# Altium schematic sheet ADC Schematic.SchDoc("ADC Schematic")
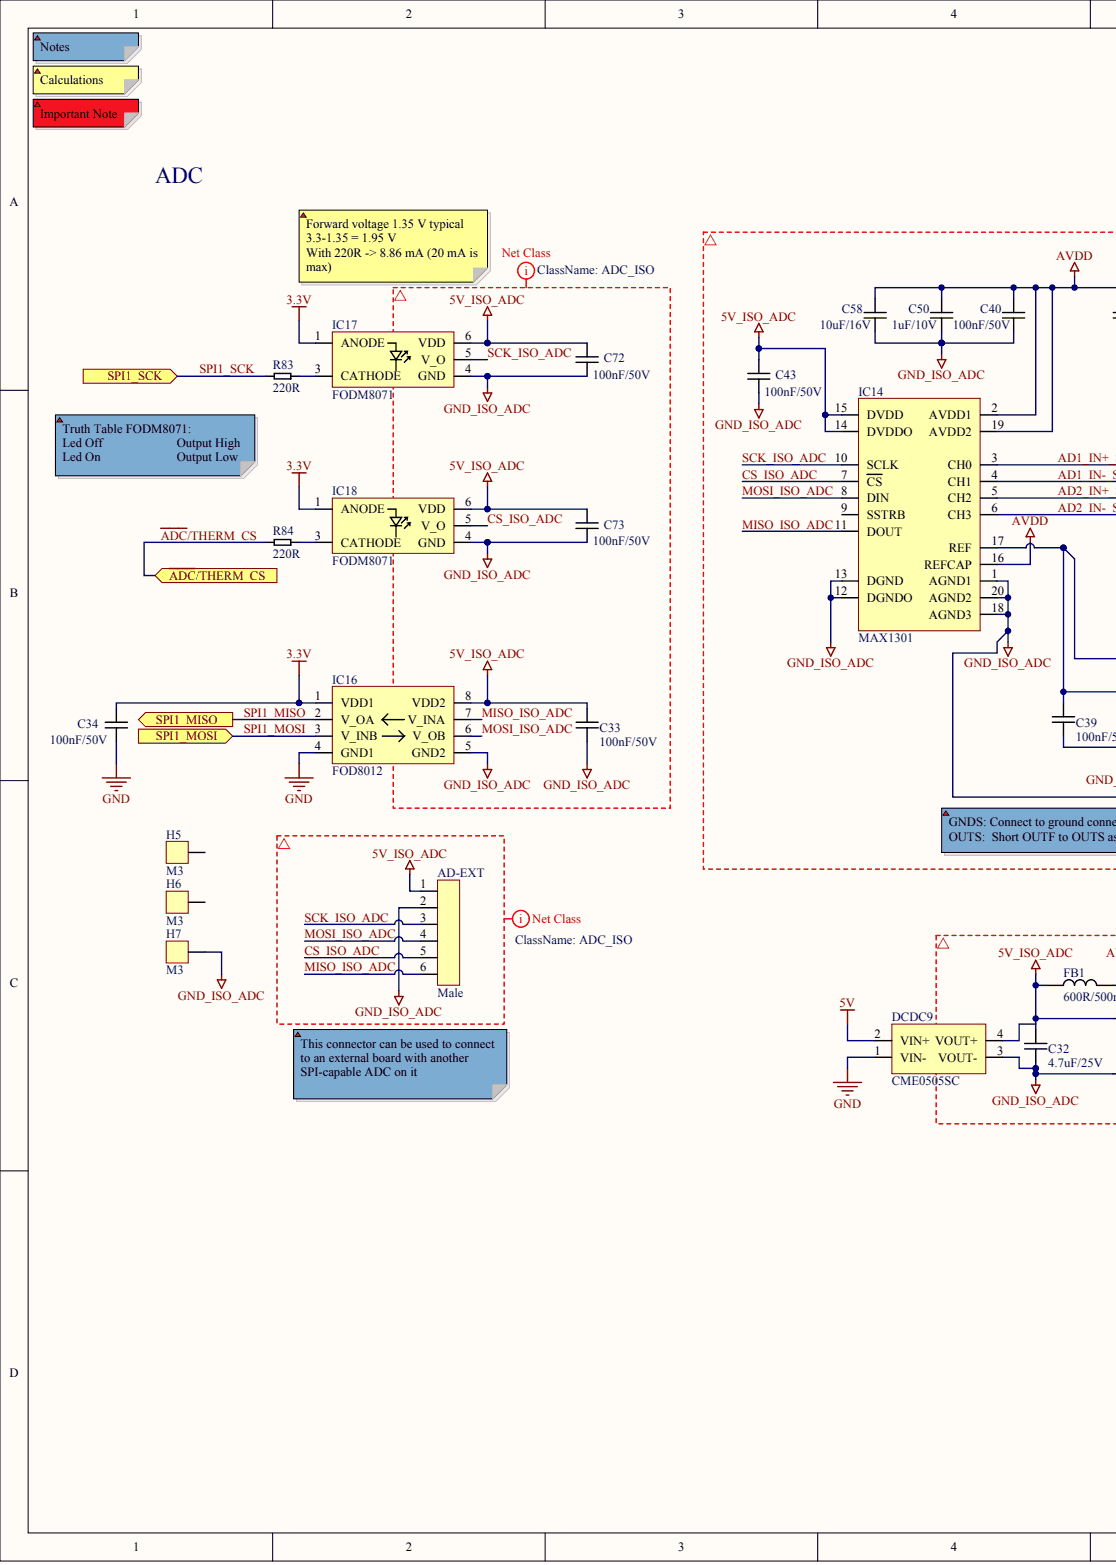
[diagram p0: ADC Schematic.SchDoc, left]
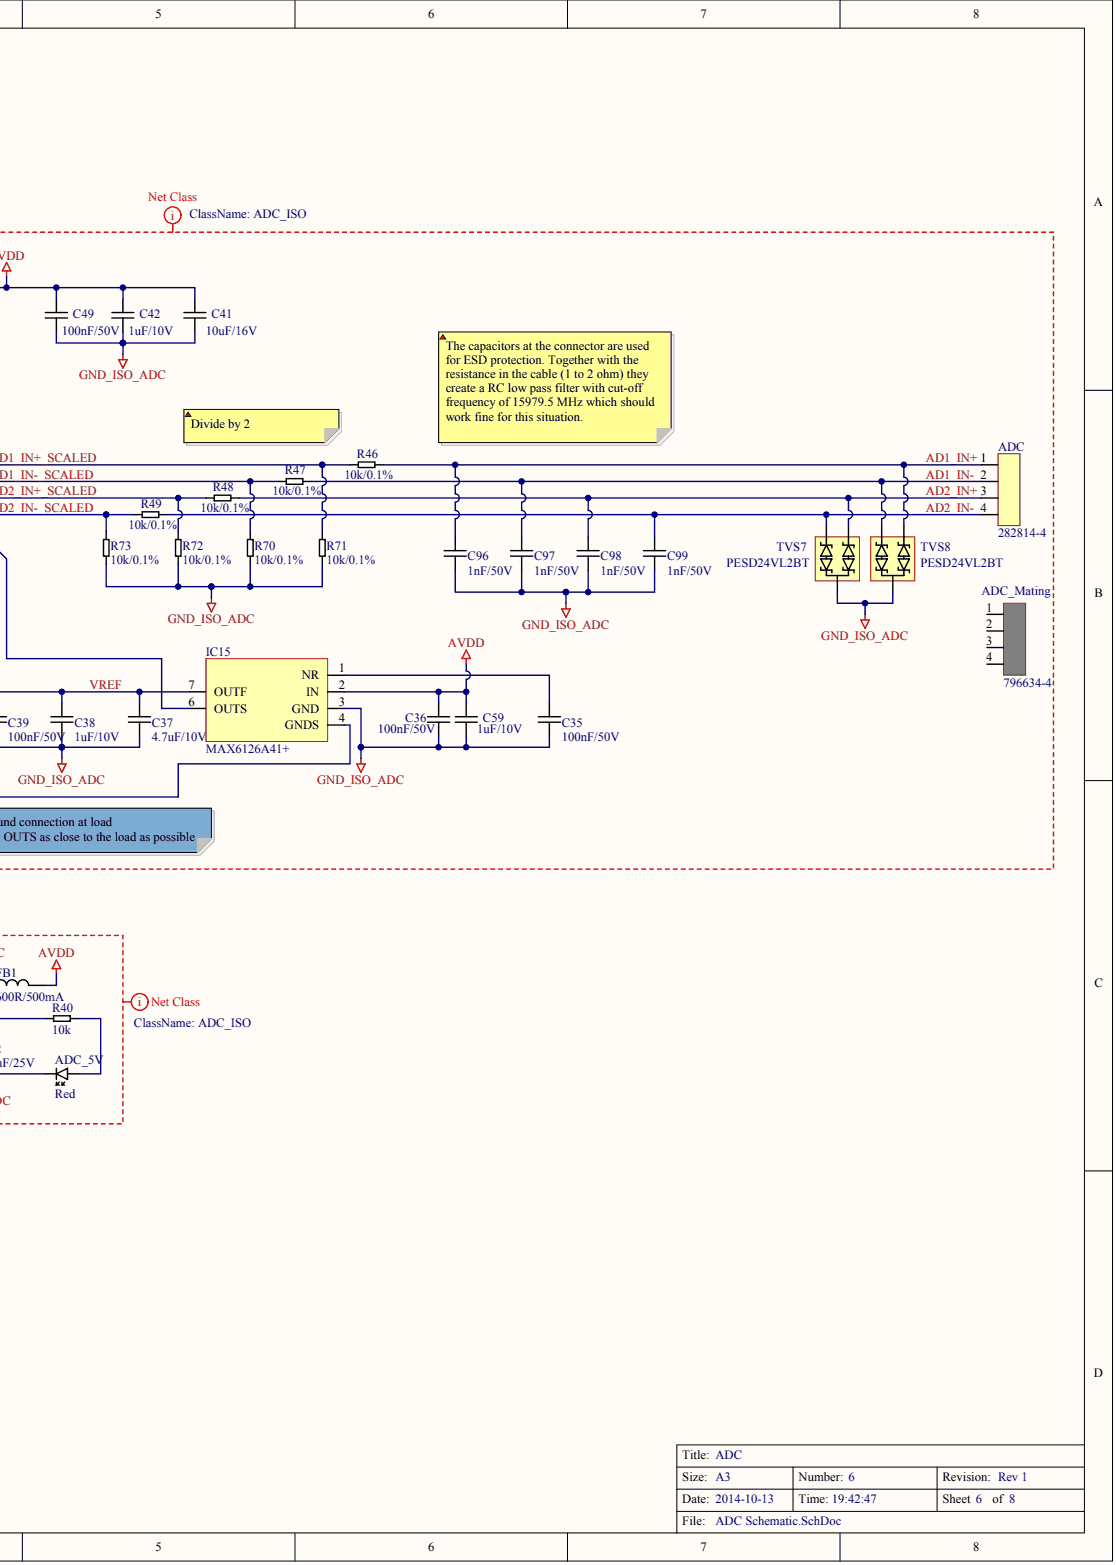
[diagram p1: ADC Schematic.SchDoc, right]

## Parts
AD-EXT  value Male  (hidden comment: Connector 1x6)
ADC  value 282814-4  Part No.: 282814-4  (hidden comment: Terminal Block 1x4 5.08mm)
ADC_5V  value Red  (hidden comment: LED_0603_Red)
ADC_Mating  value 796634-4  Part No.: 796634-4  (hidden comment: Terminal Block 1x4 5.08mm Mating Connector)
C32  value 4.7uF/25V  [0805]  (hidden comment: Cap_0805_4.7uF/25V)
C33  value 100nF/50V  [0603]  (hidden comment: Cap_0603_100nF/50V)
C34  value 100nF/50V  [0603]  (hidden comment: Cap_0603_100nF/50V)
C35  value 100nF/50V  [0603]  (hidden comment: Cap_0603_100nF/50V)
C36  value 100nF/50V  [0603]  (hidden comment: Cap_0603_100nF/50V)
C37  value 4.7uF/10V  [0603]  (hidden comment: Cap_0603_4.7uF/10V)
C38  value 1uF/10V  [0603]  (hidden comment: Cap_0603_1uF/10V)
C39  value 100nF/50V  [0603]  (hidden comment: Cap_0603_100nF/50V)
C40  value 100nF/50V  [0603]  (hidden comment: Cap_0603_100nF/50V)
C41  value 10uF/16V  [0805]  (hidden comment: Cap_0805_10uF/16V)
C42  value 1uF/10V  [0603]  (hidden comment: Cap_0603_1uF/10V)
C43  value 100nF/50V  [0603]  (hidden comment: Cap_0603_100nF/50V)
C49  value 100nF/50V  [0603]  (hidden comment: Cap_0603_100nF/50V)
C50  value 1uF/10V  [0603]  (hidden comment: Cap_0603_1uF/10V)
C58  value 10uF/16V  [0805]  (hidden comment: Cap_0805_10uF/16V)
C59  value 1uF/10V  [0603]  (hidden comment: Cap_0603_1uF/10V)
C72  value 100nF/50V  [0603]  (hidden comment: Cap_0603_100nF/50V)
C73  value 100nF/50V  [0603]  (hidden comment: Cap_0603_100nF/50V)
C96  value 1nF/50V  [0603]  (hidden comment: Cap_0603_1nF/50V)
C97  value 1nF/50V  [0603]  (hidden comment: Cap_0603_1nF/50V)
C98  value 1nF/50V  [0603]  (hidden comment: Cap_0603_1nF/50V)
C99  value 1nF/50V  [0603]  (hidden comment: Cap_0603_1nF/50V)
DCDC9  CME0505SC  [4-SIP]
FB1  value 600R/500mA  [0603]  (hidden comment: Ferrite_Bead_0603_600R_500mA)
H5  value M3  (hidden comment: M3_SCREWHOLE)
H6  value M3  (hidden comment: M3_SCREWHOLE)
H7  value M3  (hidden comment: M3_SCREWHOLE)
IC14  MAX1301
IC15  MAX6126A41+
IC16  FOD8012
IC17  FODM8071
IC18  FODM8071
R40  value 10k  [0603]  (hidden comment: Res_0603_10k)
R46  value 10k/0.1%  [0603]  (hidden comment: Res_0603_10k_0.1%)
R47  value 10k/0.1%  [0603]  (hidden comment: Res_0603_10k_0.1%)
R48  value 10k/0.1%  [0603]  (hidden comment: Res_0603_10k_0.1%)
R49  value 10k/0.1%  [0603]  (hidden comment: Res_0603_10k_0.1%)
R70  value 10k/0.1%  [0603]  (hidden comment: Res_0603_10k_0.1%)
R71  value 10k/0.1%  [0603]  (hidden comment: Res_0603_10k_0.1%)
R72  value 10k/0.1%  [0603]  (hidden comment: Res_0603_10k_0.1%)
R73  value 10k/0.1%  [0603]  (hidden comment: Res_0603_10k_0.1%)
R83  value 220R  [0603]  (hidden comment: Res_0603_220R)
R84  value 220R  [0603]  (hidden comment: Res_0603_220R)
TVS7  PESD24VL2BT
TVS8  PESD24VL2BT

## Ports
SPI1_MISO, SPI1_MOSI, SPI1_SCK, ~{ADC}/THERM_CS

## Nets
3.3V: C34.2 IC16.1(VDD1) IC17.1(ANODE) IC18.1(ANODE)
5V: DCDC9.2(VIN+)
5V_ISO_ADC: AD-EXT.1 C32.2 C33.2 C43.2 C72.2 C73.2 DCDC9.4(VOUT+) FB1.1 IC14.14(DVDDO) IC14.15(DVDD) IC16.8(VDD2) IC17.6(VDD) IC18.6(VDD) R40.2
AVDD: C36.2 C40.2 C41.2 C42.2 C49.2 C50.2 C58.2 C59.2 FB1.2 IC14.2(AVDD1) IC14.16(REFCAP) IC14.19(AVDD2) IC15.2(IN)
GND: C34.1 DCDC9.1(VIN-) IC16.4(GND1)
GND_ISO_ADC: AD-EXT.2 ADC_5V.CATHODE C32.1 C33.1 C35.1 C36.1 C37.1 C38.1 C39.1 C40.1 C41.1 C42.1 C43.1 C49.1 C50.1 C58.1 C59.1 C72.1 C73.1 C96.1 C97.1 C98.1 C99.1 DCDC9.3(VOUT-) H7.HOLE IC14.1(AGND1) IC14.12(DGNDO) IC14.13(DGND) IC14.18(AGND3) IC14.20(AGND2) IC15.3(GND) IC15.4(GNDS) IC16.5(GND2) IC17.4(GND) IC18.4(GND) R70.1 R71.1 R72.1 R73.1 TVS7.3 TVS8.3
~{ADC}/THERM_CS: R84.1 ; port ~{ADC}/THERM_CS
AD1_IN+_SCALED: IC14.3(CH0) R46.2 R71.2
AD1_IN-: ADC.2 C97.2 R47.1 TVS8.2
AD1_IN-_SCALED: IC14.4(CH1) R47.2 R70.2
AD2_IN+: ADC.3 C98.2 R48.1 TVS7.1
AD2_IN+_SCALED: IC14.5(CH2) R48.2 R72.2
AD2_IN-: ADC.4 C99.2 R49.1 TVS7.2
AD2_IN-_SCALED: IC14.6(CH3) R49.2 R73.2
CS_ISO_ADC: AD-EXT.5 IC14.7(CS) IC18.5(V_O)
MISO_ISO_ADC: AD-EXT.6 IC14.11(DOUT) IC16.7(V_INA)
MOSI_ISO_ADC: AD-EXT.4 IC14.8(DIN) IC16.6(V_OB)
SCK_ISO_ADC: AD-EXT.3 IC14.10(SCLK) IC17.5(V_O)
SPI1_MISO: IC16.2(V_OA) ; port SPI1_MISO
SPI1_MOSI: IC16.3(V_INB) ; port SPI1_MOSI
SPI1_SCK: R83.1 ; port SPI1_SCK
VREF: C37.2 C38.2 C39.2 IC14.17(REF) IC15.6(OUTS) IC15.7(OUTF)
NetADC_1: ADC.1 C96.2 R46.1 TVS8.1
NetADC_5V_ANODE: ADC_5V.ANODE R40.1
NetC35_2: C35.2 IC15.1(NR)
NetIC17_3: IC17.3(CATHODE) R83.2
NetIC18_3: IC18.3(CATHODE) R84.2
AD1_IN+:
N00031:
N00032:
N00033:
N00034:

Not connected: ADC_Mating 1 2 3 4; H5 HOLE; H6 HOLE; IC14 9(SSTRB)

## Library data of the parts
ADC = (no symbol name): Manufacturer: TE Connectivity; Mating Connector: 796634-4; Mouser: 571-282814-4; RS: *; DigiKey: A98067-ND; Elfa: *; Price SEK (No VAT): 8
ADC_Mating = (no symbol name): Manufacturer: TE Connectivity; Mouser: 571-7966344; RS: *; DigiKey: A98225-ND; Elfa: *; Price SEK (No VAT): 18
C37 = (no symbol name): Part No.: C1608X5R1A475K; Manufacturer: TDK; Mouser: 810-C1608X5R1A475K; Price SEK (No VAT): 1; DigiKey: 445-5170-1-ND
C38 C42 C50 C59 = (no symbol name): Part No.: C1608X5R1A105K080AC; Manufacturer: TDK; Mouser: 810-C1608X5R1A105K; Price SEK (No VAT): 1; DigiKey: 445-1321-1-ND
DCDC9 = (no symbol name): Manufacturer: Murata; DigiKey: 811-2894-5-ND; Part No.: CME0505SC; Mouser: 580-CME0505SC; Datasheet: http://www.murata-ps.com/data/power/ncl/kdc_cme.pdf; Price SEK (No VAT): 28
FB1 = (no symbol name): Part No.: MMZ1608B601C; Manufacturer: TDK; RS: 786-5015; Price SEK (No VAT): 1; Mouser: 810-MMZ1608B601C; DigiKey: 445-2166-1-ND; Farnell: 1669687
IC14 = (no symbol name): Part No.: MAX1301BEUP+; Manufacturer: Maxim; DigiKey: MAX1301BEUP+-ND; Price SEK (No VAT): 79; Datasheet: http://datasheets.maximintegrated.com/en/ds/MAX1300-MAX1301.pdf; Mouser: 700-MAX1301BEUP; Value: MAX1301
IC15 = (no symbol name): Manufacturer: Maxim; RS: 783-3901; DigiKey: MAX6126A41+-ND; Part No.: MAX6126A41+; Price SEK (No VAT): 32; Datasheet: http://datasheets.maximintegrated.com/en/ds/MAX6126.pdf; Mouser: 700-MAX6126A41; Value: MAX6126A41+
IC16 = (no symbol name): Manufacturer: Fairchild Semiconductor; Note: Should be able to replace ADUM1201 as pin-compatible; DigiKey: FOD8012-ND; Part No.: FOD8012; Price SEK (No VAT): 31; Datasheet: http://www.fairchildsemi.com/ds/FO/FOD8012.pdf; Mouser: 512-FOD8012; Value: FOD8012
IC17 IC18 = (no symbol name): Manufacturer: Fairchild Semiconductor; DigiKey: FODM8071-ND; Part No.: FODM8071; Price SEK (No VAT): 14; Datasheet: http://www.fairchildsemi.com/ds/FO/FODM8071.pdf; Mouser: 512-FODM8071; Value: FODM8071
R46-R49 R70-R73 = (no symbol name): Part No.: TNPW060310K0BEEA; Manufacturer: Vishay; Price (No VAT): 3; Mouser: 71-TNPW060310K0BEEA; DigiKey: TNP10.0KAACT-ND; Farnell: 1835484
TVS7 TVS8 = (no symbol name): Manufacturer: NXP; Part No.: PESD24VL2BT,215; Mouser: 771-PESD24VL2BT-T/R; DigiKey: 568-4035-1-ND; Price SEK (No VAT): 4; Value: PESD24VL2BT
AD-EXT ADC_5V C32-C36 C39-C41 C43 C49 C58 C72 C73 C96-C99 H5-H7 R40 R83 R84 = (no symbol name)
  R40 R83 R84: Price (No VAT): 0,1
